# Altium schematic sheet NeoPixels and OLED Display.SchDoc
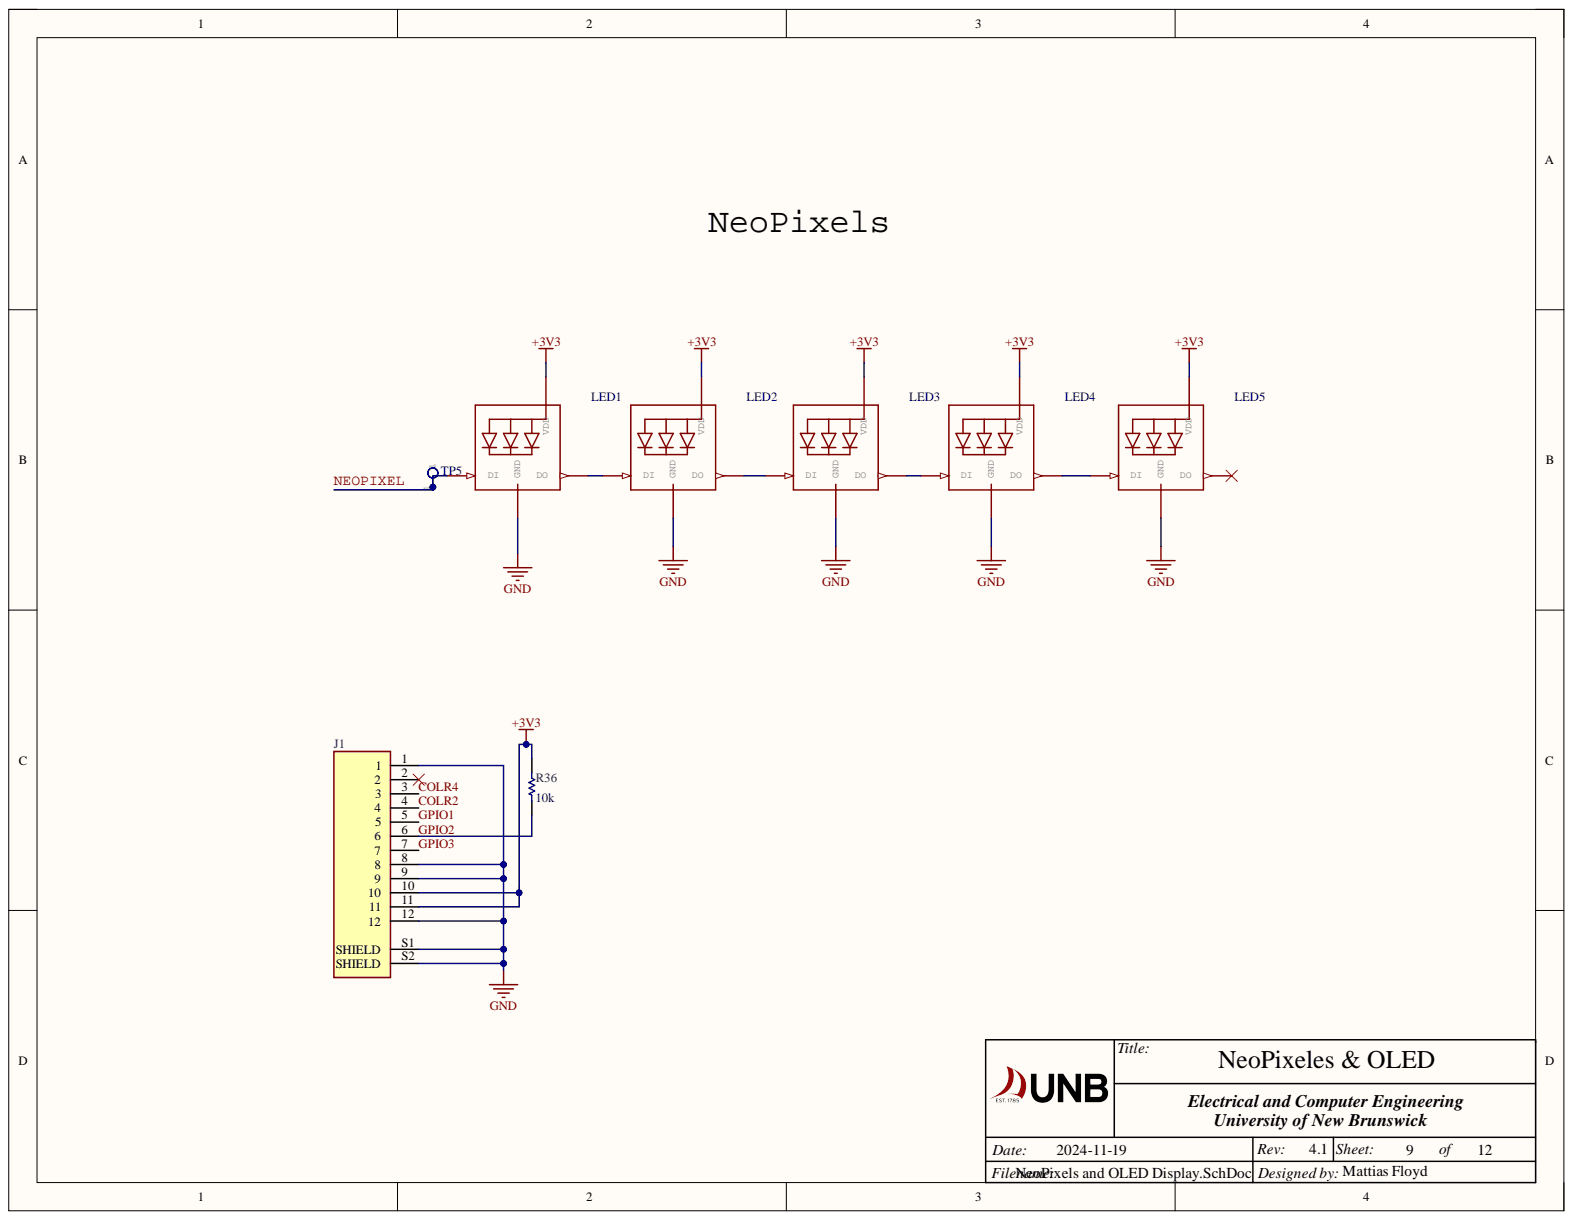
[diagram p0: NeoPixels and OLED Display.SchDoc]

## Parts
J1  1-1734839-2  [TE_1-1734839-2]  (value not drawn)
LED1  LED BB-HRGB32M-TRB-1  [BL-HRGB32M-TRB]  (value not drawn)
LED2  LED BB-HRGB32M-TRB-1  [BL-HRGB32M-TRB]  (value not drawn)
LED3  LED BB-HRGB32M-TRB-1  [BL-HRGB32M-TRB]  (value not drawn)
LED4  LED BB-HRGB32M-TRB-1  [BL-HRGB32M-TRB]  (value not drawn)
LED5  LED BB-HRGB32M-TRB-1  [BL-HRGB32M-TRB]  (value not drawn)
R36  10k  [0603 Resistor]
TP5  Surface test point pad  [TEST_POINT]  (value not drawn)

## Nets
+3V3: J1.10 J1.11 LED1.1(VDD) LED2.1(VDD) LED3.1(VDD) LED4.1(VDD) LED5.1(VDD) R36.1
GND: J1.1 J1.8 J1.9 J1.12 J1.S1(SHIELD) J1.S2(SHIELD) LED1.3(GND) LED2.3(GND) LED3.3(GND) LED4.3(GND) LED5.3(GND)
COLR2: J1.4
COLR4: J1.3
GPIO1: J1.5
GPIO2: J1.6 R36.2
GPIO3: J1.7
NEOPIXEL: LED1.4(DI) TP5.1
NetLED1_2: LED1.2(DO) LED2.4(DI)
NetLED2_2: LED2.2(DO) LED3.4(DI)
NetLED3_2: LED3.2(DO) LED4.4(DI)
NetLED4_2: LED4.2(DO) LED5.4(DI)

Not connected: J1 2; LED5 2(DO)

## Library data of the parts
J1 = 1-1734839-2: Ffc & Fpc Connectors Fpc Conn 0.5MM Pitch T/c 12P; Manufacturer: TE Connectivity; Actuator Material: Thermoplastic; Connector System: Flex-to-Board; Connector Type: Receptacle,Connector,Socket; Contact Current Rating: 500mA; Contact Location: Top; Gender: Receptacle; Mating Alignment: Yes (With); Number of Contacts: 12; PCB Mount Alignment: No (Without); PCB Mount Retention: With; PCB Mounting Orientation: Right Angle; Shielding: Unshielded; Voltage Rating: 250V; Wire/Cable Type: Flat Flex; Category: FFC / FPC; Component Kind: Standard; ComponentLink1Description: https://datasheet.ciiva.com/669/eng-cd-1734839-c-c-1734839-669216.pdf?src-supplier=Digi-Key; ComponentLink1URL: https://datasheet.ciiva.com/669/eng-cd-1734839-c-c-1734839-669216.pdf?src-supplier=Digi-Key; ComponentLink2Description: https://datasheet.ciiva.com/1437/eng-ds-1-1773440-6-0506-1437320.pdf?src-supplier=Element14; ComponentLink2URL: https://datasheet.ciiva.com/1437/eng-ds-1-1773440-6-0506-1437320.pdf?src-supplier=Element14; ComponentLink3Description: https://datasheet.ciiva.com/5826/1652225-5826989.pdf?src-supplier=Farnell; ComponentLink3URL: https://datasheet.ciiva.com/5826/1652225-5826989.pdf?src-supplier=Farnell; ComponentLink4Description: https://datasheet.ciiva.com/16660/eng-ds-1-1773440-6-0506-16660093.pdf?src-supplier=Avnet+Europe; ComponentLink4URL: https://datasheet.ciiva.com/16660/eng-ds-1-1773440-6-0506-16660093.pdf?src-supplier=Avnet+Europe; ComponentLink5Description: https://datasheet.ciiva.com/669/eng-cd-1734839-c-c-1734839-669214.pdf?src-supplier=Digi-Key; ComponentLink5URL: https://datasheet.ciiva.com/669/eng-cd-1734839-c-c-1734839-669214.pdf?src-supplier=Digi-Key; ComponentLink6Description: https://datasheet.ciiva.com/669/eng-cd-1734839-c-c-1734839-669215.pdf?src-supplier=Digi-Key; ComponentLink6URL: https://datasheet.ciiva.com/669/eng-cd-1734839-c-c-1734839-669215.pdf?src-supplier=Digi-Key; Contact Material: Bronze; Contact Plating: Gold; Current Rating: 500mA; Depth: 5.1mm; Flammability Rating: UL94 V-0; Height: 2.05mm; Housing Color: Natural; Housing Material: Polymer; Length: 11.43mm; Library Name: 1-1734839-2.IntLib; Manufacturer Part Number: 1-1734839-2; Max Operating Temperature: 85°C; Min Operating Temperature: -40°C; Mount: Surface Mount; Number of Positions: 12; Number of Rows: 1; Orientation: Right Angle; Package Quantity: 2000; Packaging: Tape and Reel; Pitch: 0.5mm; Radiation Hardening: No; RoHS: Yes; Sealable: No (Without); Shrouded: Yes (With); Stock 1: 38540; Supplier 1: Digi-Key; Supplier Part Number 1: A100294CT-ND; Termination: SMD/SMT; Width: 5.1mm
LED1-LED5 = LED BB-HRGB32M-TRB-1: Emitted Color Red, Green, Blue,; Manufacturer: American Bright; Component Kind: Standard; Manufacturer Part Number: BB-HRGB32M-TRB-1; Pricing 1: 1=1.34, 10=0.848, 100=0.6278, 500=0.57692, 1000=0.4751 (USD); RoHS: No; Stock 1: 71973; Supplier 1: Digikey; Supplier Part Number 1: 2007-BB-HRGB32M-TRB-1CT-ND; Library Name: bBoard for UNB Rev 4.SCHLIB
R36 = Res Semi: Res Thick Film 0603 10K Ohm 1% 0.1W(1/10W) ±100ppm/C Molded SMD Automotive T/R; Manufacturer: Stackpole Electronics; Manufacturer Part Number: RMCF0603FT10K0; Max Power Dissipation: 100mW; Resistance: 10kR; Temperature Coefficient: 100ppm/°C; Case Code (Imperial): 0603; Case Code (Metric): 1608; Case/Package: 0603; Category: Chip SMD Resistors; Component Kind: Standard; ComponentLink1Description: http://www.rohm.com/web/global/datasheet/ESR01MZPF/esr-e; ComponentLink1URL: http://www.rohm.com/web/global/datasheet/ESR01MZPF/esr-e; ComponentLink2Description: http://components.arrow.com/part/dataview/43747082S6756917N1174?src-supplier=Arrow+Electronics; ComponentLink2URL: http://components.arrow.com/part/dataview/43747082S6756917N1174?src-supplier=Arrow+Electronics; ComponentLink3Description: https://www.seielect.com/catalog/sei-rmcf_rmcp.pdf?src-supplier=Digi-Key; ComponentLink3URL: https://www.seielect.com/catalog/sei-rmcf_rmcp.pdf?src-supplier=Digi-Key; ComponentLink4Description: https://www.seielect.com/catalog/SEI-RMCF_RMCP.pdf?src-supplier=Avnet; ComponentLink4URL: https://www.seielect.com/catalog/SEI-RMCF_RMCP.pdf?src-supplier=Avnet; ComponentLink5Description: http://www.seielect.com/catalog/sei-rmc.pdf?src-supplier=Future+Electronics; ComponentLink5URL: http://www.seielect.com/catalog/sei-rmc.pdf?src-supplier=Future+Electronics; ComponentLink6Description: https://www.verical.com/server-webapp/html/getDatasheet?partID=360913&src-supplier=Verical; ComponentLink6URL: https://www.verical.com/server-webapp/html/getDatasheet?partID=360913&src-supplier=Verical; Composition: Thick Film; Contact Plating: Tin,Matte; Depth: 0.8mm; Features: Automotive AEC-Q200; Height: 0.022inch; Lead Free: Lead Free; Length: 1.55mm; Max Operating Temperature: 155°C; Military Standard: Not; Min Operating Temperature: -55°C; Mount: Surface Mount; Number of Terminations: 2; Packaging: Tape and Reel; Power Rating: 100mW; Radiation Hardening: No; RoHS: Yes; Signal Integrity: Res Semi; Simulation: RES; Stock 1: 1608506; Supplier 1: Digi-Key; Supplier Part Number 1: RMCF0603FT10K0CT-ND; Tolerance: 1%; Value: 10k; Width: 0.031inch; Library Name: BrillianBit.SCHLIB
TP5 = TESTPOINT: GateName_1: A; SymbolName_1: TP; Component Kind: Standard; DeviceSetName: TESTPOINT; Package: TEST_POINT; Library Name: bBoard for UNB Schematic Library.SCHLIB; LibraryName: BrilliantLabsEagleLibrary
